# Altium schematic sheet NU.N8051.EK04.001.SCHDOC
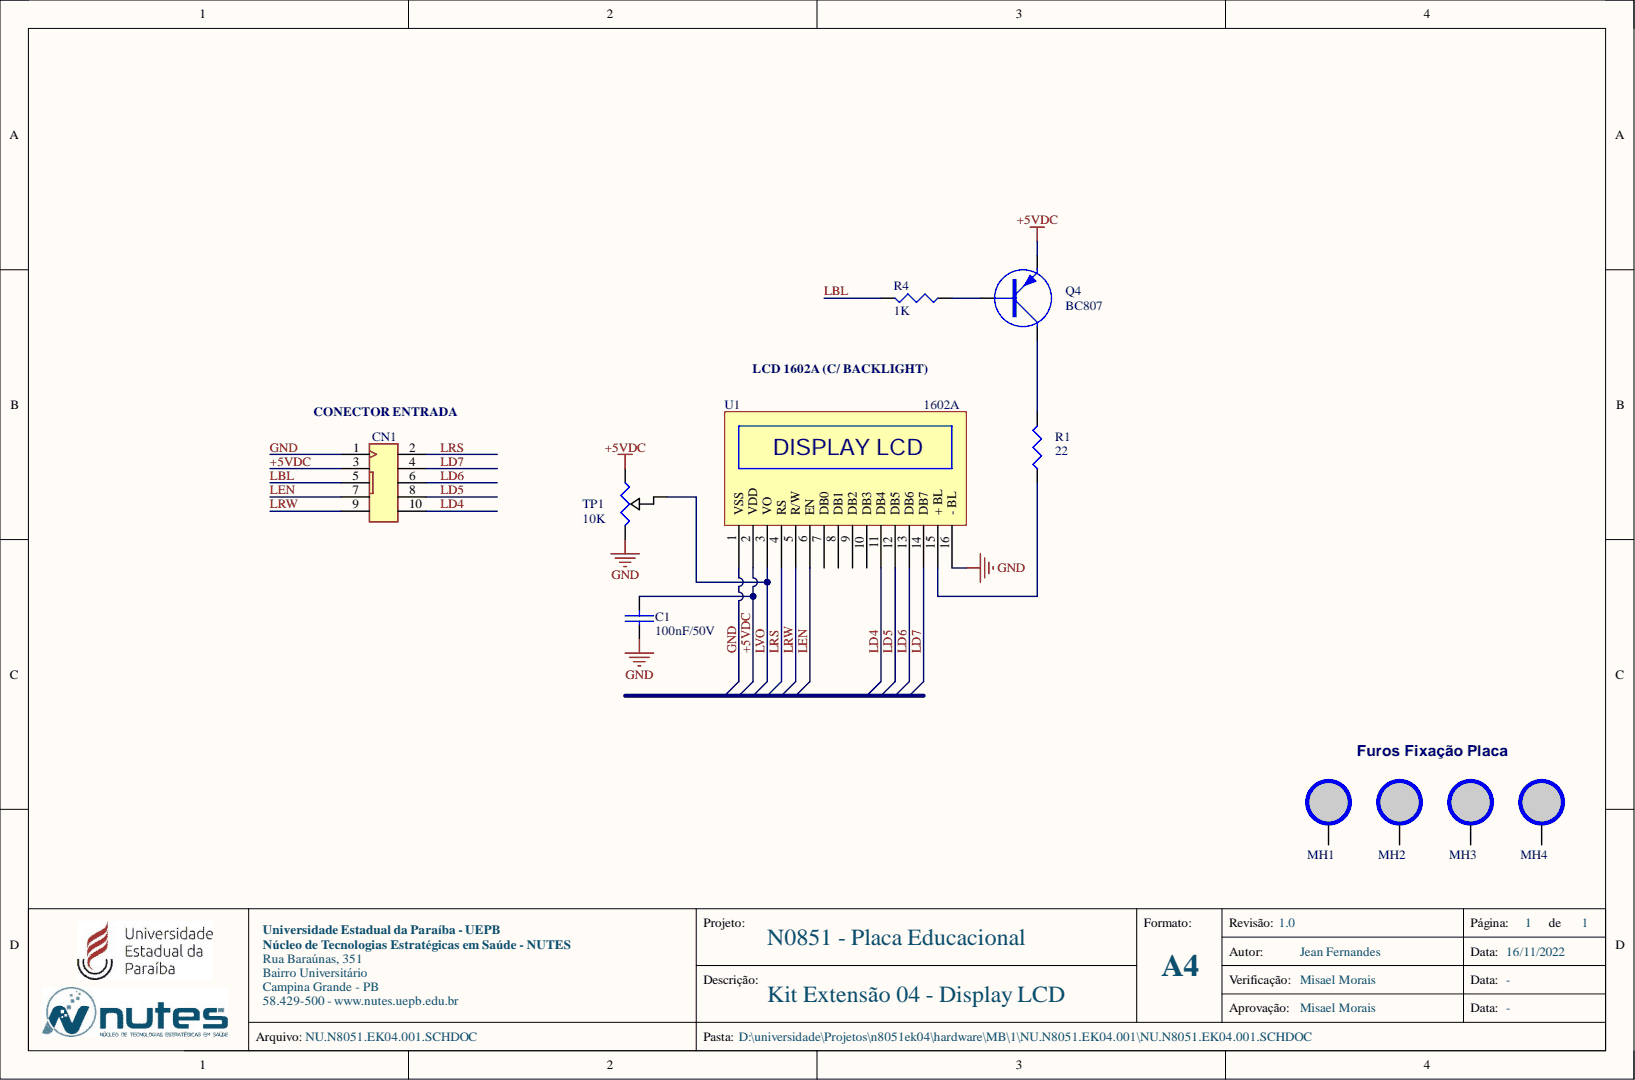
[diagram p0: NU.N8051.EK04.001.SCHDOC]

## Parts
C1  value 100nF/50V  [CAP-0603-IPC-MD]  (hidden comment: CAPACITOR)
CN1  IDCEC-10-LP  [IDCEC-10-LP]  (value not drawn)
MH1  MH6MM4MM  [MOUNTING_HOLE]  (value not drawn)  (no BOM)
MH2  MH6MM4MM  [MOUNTING_HOLE]  (value not drawn)  (no BOM)
MH3  MH6MM4MM  [MOUNTING_HOLE]  (value not drawn)  (no BOM)
MH4  MH6MM4MM  [MOUNTING_HOLE]  (value not drawn)  (no BOM)
Q4  value BC807  [SOT95P245X110-3M]  (hidden comment: TRANSISTOR - PNP)
R1  value 22  [RES300]  (hidden comment: RESISTOR)
R4  value 1K  [RES-0603-IPC-MD]  (hidden comment: RESISTOR)
TP1  value 10K  [3386F-TRIMMER]  (hidden comment: TRIMPOT)
U1  value 1602A  [LCD16x2]  (hidden comment: LCD16x2)

## Nets
+5VDC: C1.2 CN1.3 Q4.2 TP1.1 U1.2(VDD)
GND: C1.1 CN1.1 TP1.3 U1.1(VSS) U1.16(-_BL)
LBL: CN1.5 R4.2
LD4: CN1.10 U1.11(DB4)
LD5: CN1.8 U1.12(DB5)
LD6: CN1.6 U1.13(DB6)
LD7: CN1.4 U1.14(DB7)
LEN: CN1.7 U1.6(EN)
LRS: CN1.2 U1.4(RS)
LRW: CN1.9 U1.5(R/W)
LVO: TP1.2 U1.3(VO)
NetQ4_1: Q4.1 R4.1
NetQ4_3: Q4.3 R1.2
NetR1_1: R1.1 U1.15(+_BL)

Not connected: MH1 1; MH2 1; MH3 1; MH4 1; U1 7(DB0) 8(DB1) 9(DB2) 10(DB3)

## Library data of the parts
C1 = CAPACITOR: General Purpose Capacitor; Manufacturer: Murata Electronics; Manufacturer Part Number: GRM188R71H104KA93D; Component Kind: Standard; Datasheet: https://datasheet.lcsc.com/lcsc/1810311126_Murata-Electronics-GRM188R71H104KA93D_C77055.pdf; Package: 0603; Price: 0.0049; Supplier: JLCPCB; Supplier Link: https://jlcpcb.com/; Supplier Part Number: C77055; Library Name: NUTES_GENERAL.SCHLIB
CN1 = IDCEC-10-LP: 2.54mm Straight 5 Push - Pull 2.54mm 2 Plugin,P=2.54mm IDC Connectors ROHS; Manufacturer: BOOMELE(Boom Precision Elec); Manufacturer Part Number: C5665; Value: C5665; Component Kind: Standard; Datasheet: https://datasheet.lcsc.com/lcsc/1810281612_BOOMELE-Boom-Precision-Elec-C5665_C5665.pdf; Package: IDC-TH_10P-P2.54_C5665; Price: 0.0731; Signal Integrity: Guessed Model; Supplier: JLCPCB; Supplier Link: https://jlcpcb.com/; Supplier Part Number: C5665; Library Name: NUTES_GENERAL.SCHLIB
MH1-MH4 = MH6MM4MM: Mounting Hole 6mm x 4 mm; Library Name: NUTES_GENERAL.SCHLIB
Q4 = TRANSISTOR - PNP: General Purpose PNP Transistor; Manufacturer: Nexperia; Manufacturer Part Number: BC807-40,215; Component Kind: Standard; Datasheet: https://datasheet.lcsc.com/lcsc/1811141223_Nexperia-BC807-40-215_C57316.pdf; Package: SOT23; Price: 0.025; Supplier: JLCPCB; Supplier Link: https://jlcpcb.com/partdetail/Nexperia-BC807_40215/C57316; Supplier Part Number: C57316; Library Name: NUTES_GENERAL.SCHLIB
R1 = RESISTOR: General Purpose Resistor; Manufacturer: Uni-royal; Manufacturer Part Number: 0603WAF220JT5E; Component Kind: Standard; Datasheet: https://datasheet.lcsc.com/lcsc/2206010100_UNI-ROYAL-Uniroyal-Elec-0603WAF220JT5E_C23345.pdf; Package: 0603; Price: 0.0010; Supplier: JLCPCB; Supplier Link: https://jlcpcb.com/; Supplier Part Number: C23345; Library Name: NUTES_GENERAL.SCHLIB
R4 = RESISTOR: General Purpose Resistor; Manufacturer: Uni-royal; Manufacturer Part Number: 0603WAF1001T5E; Component Kind: Standard; Datasheet: https://datasheet.lcsc.com/lcsc/2206010130_UNI-ROYAL-Uniroyal-Elec-0603WAF1001T5E_C21190.pdf; Package: 0603; Price: 0.001; Supplier: JLCPCB; Supplier Link: https://jlcpcb.com/; Supplier Part Number: C21190; Library Name: NUTES_GENERAL.SCHLIB
TP1 = TRIMPOT: General Purpose Trimpot; Component Kind: Standard; Library Name: NUTES_GENERAL.SCHLIB
U1 = LCD16x2: Display LCD, 16x2, com backlight; Component Kind: Standard; Library Name: NUTES_GENERAL.SCHLIB
